# Altium schematic sheet RemoteTrigger.SchDoc
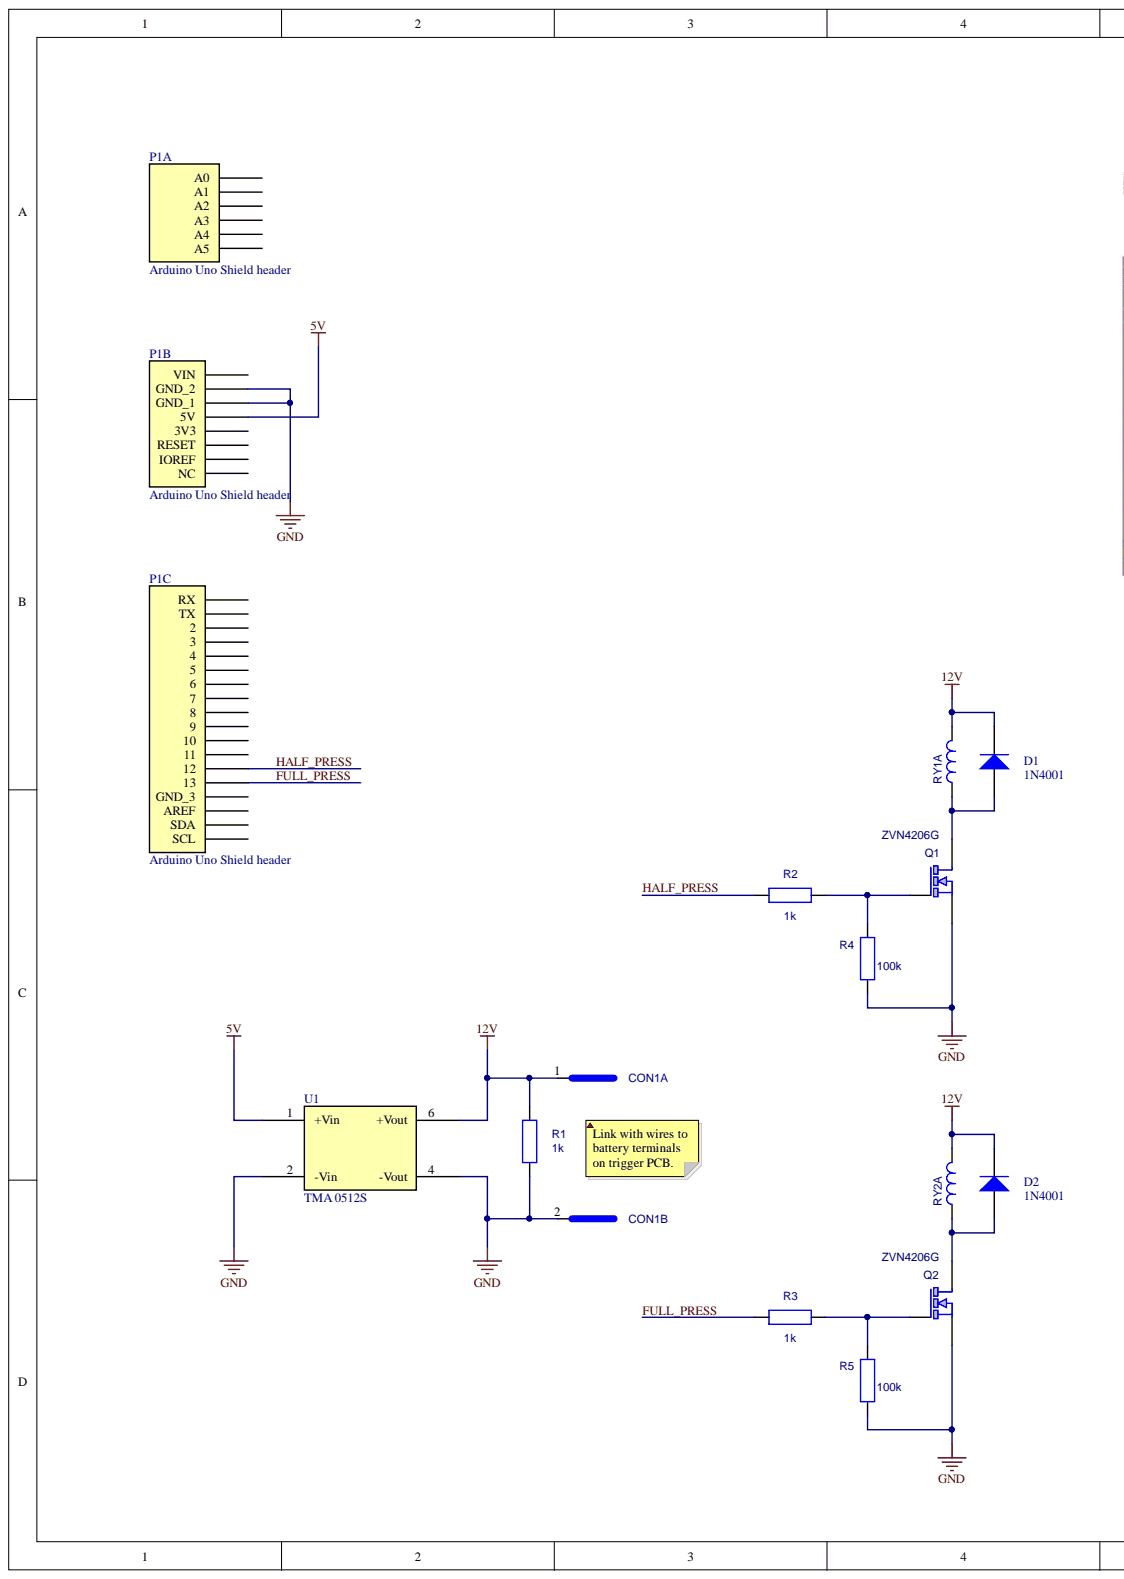
[diagram p0: RemoteTrigger.SchDoc, left]
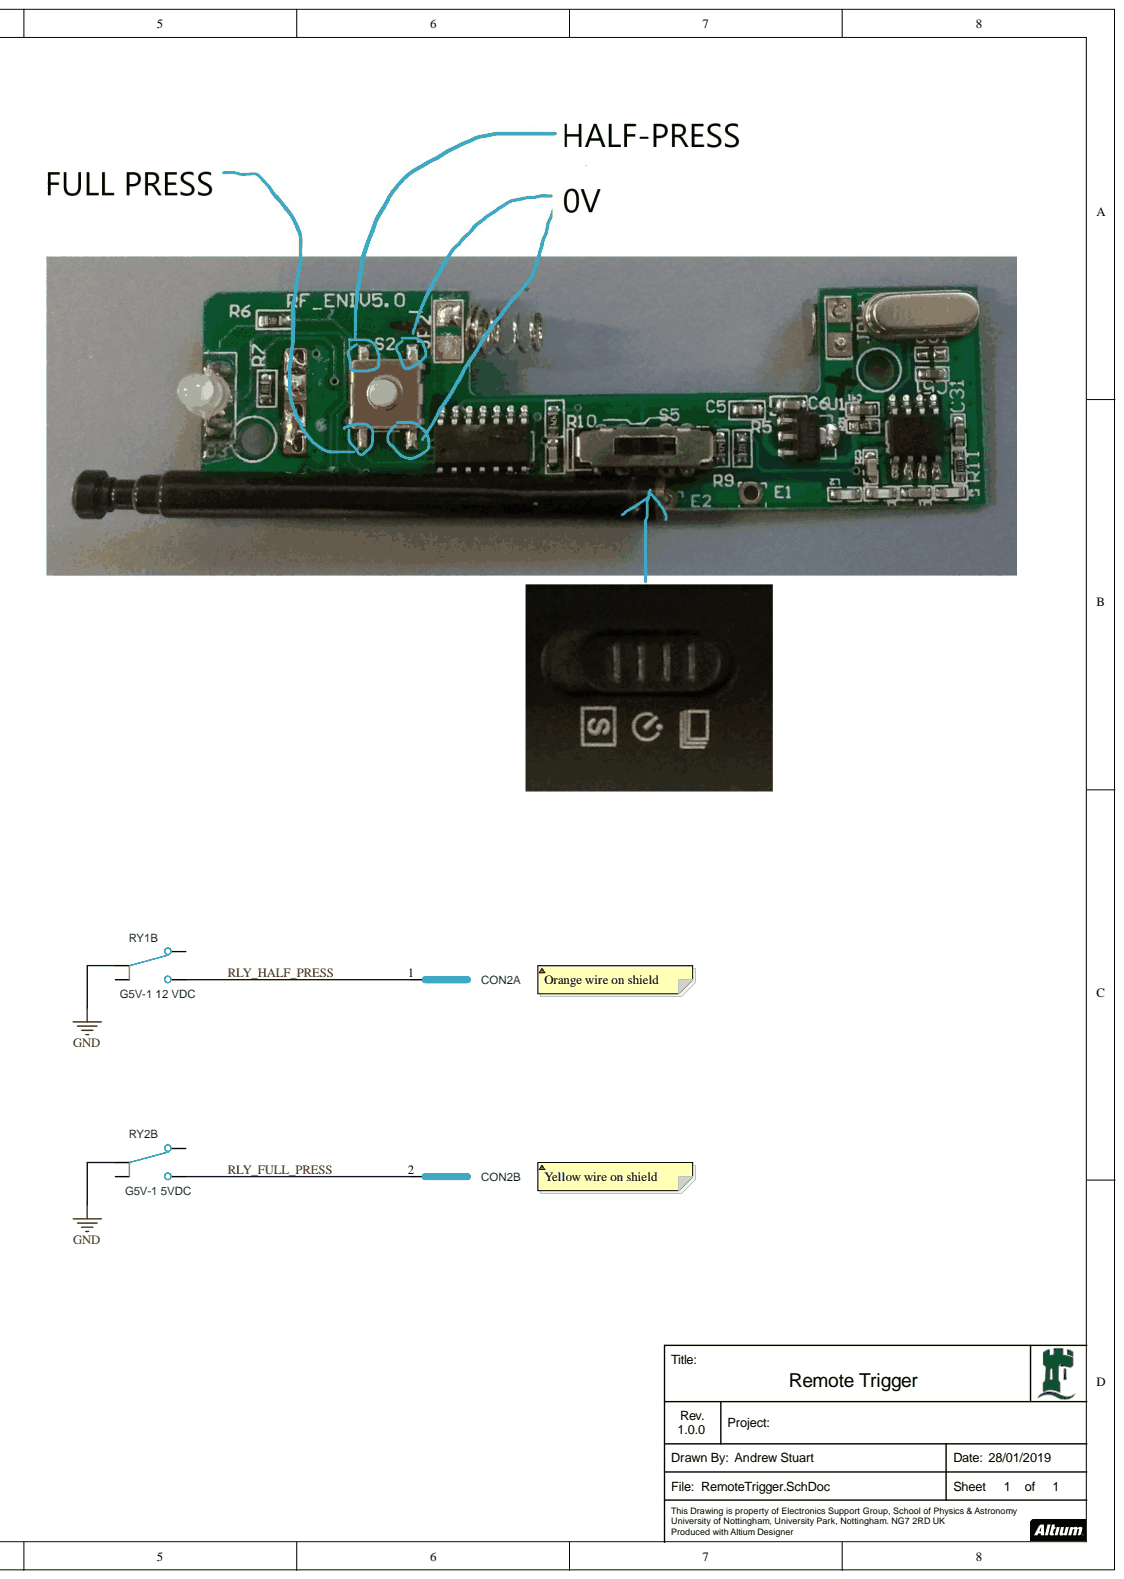
[diagram p1: RemoteTrigger.SchDoc, right]

## Parts
CON1  22-27-2021  [22-27-2021]  (value not drawn)  (parts A,B)
CON2  22-27-2021  [22-27-2021]  (value not drawn)  (parts A,B)
D1  1N4001  [DIO10.46-5.3x2.8]
D2  1N4001  [DIO10.46-5.3x2.8]
P1  Arduino Uno Shield header  [ARD_UNO_NOISCP]  (parts A,B,C)
Q1  ZVN4206G  [E-LINE]
Q2  ZVN4206G  [E-LINE]
R1  value 1k  [MRS25]  (hidden comment: MRS25)
R2  value 1k  [MRS25]  (hidden comment: MRS25)
R3  value 1k  [MRS25]  (hidden comment: MRS25)
R4  value 100k  [MRS25]  (hidden comment: MRS25)
R5  value 100k  [MRS25]  (hidden comment: MRS25)
RY1  G5V-1 12 VDC  [G5V-1]  (parts A,B)
RY2  G5V-1 5VDC  [G5V-1]  (parts A,B)
U1  TMA 0512S  [TMA XXXXS]

## Nets
5V: P1.5V U1.1(+Vin)
12V: CON1.1 D1.2 D2.2 R1.2 RY1.5 RY2.5 U1.6(+Vout)
GND: CON1.2 P1.GND_1 P1.GND_2 Q1.3 Q2.3 R1.1 R4.1 R5.1 RY1.3 RY2.3 U1.2(-Vin) U1.4(-Vout)
FULL_PRESS: P1.13 R3.1
HALF_PRESS: P1.12 R2.1
RLY_FULL_PRESS: CON2.2 RY2.6
RLY_HALF_PRESS: CON2.1 RY1.6
NetD1_1: D1.1 Q1.1 RY1.2
NetD2_1: D2.1 Q2.1 RY2.2
NetQ1_2: Q1.2 R2.2 R4.2
NetQ2_2: Q2.2 R3.2 R5.2

Not connected: P1 2 3 3V3 4 5 6 7 8 9 10 11 A0 A1 A2 A3 A4 A5 AREF GND_3 IOREF NC RESET RX SCL SDA TX VIN; RY1 1 4; RY2 1 4

## Library data of the parts
D1 D2 = Diode 1N4001: 1 Amp General Purpose Rectifier; Code_JEDEC: DO-204-AL; Component Kind: Standard; ComponentLink1Description: Manufacturer Link; ComponentLink1URL: http://www.fairchildsemi.com/; ComponentLink2Description: Datasheet; ComponentLink2URL: http://www.fairchildsemi.com/ds/1N%2F1N4001.pdf; DatasheetVersion: 1998; LatestRevisionDate: 17-Jul-2002; LatestRevisionNote: Re-released for DXP Platform.; Library Name: Miscellaneous Devices.IntLib; PackageReference: DO-41; PackageVersion: Aug-1999; Published: 8-Jun-2000; Signal Integrity: 1N4001; Simulation: 1N4001; Publisher: Altium Limited
P1 = ArduinoUno: Component Kind: Standard; Library Name: AS_connector.IntLib
Q1 Q2 = ZVN4206G: N-Channel MOSFET Enhancement mode DMOS ZVN4206A; Component Kind: Standard; Library Name: AS_transistors.IntLib; Simulation: ZVN4206G
R1-R5 = MRS25: Resistor through hole 0.6W; Component Kind: Standard; Library Name: AS_resistor.IntLib; Simulation: RESISTOR
RY2 = G5V-1 12 VDC: Component Kind: Standard; Description: G5V-1 12 VDC; Library Name: Omron Relay.IntLib
U1 = TMA_XXXXS: DC-DC converter, 1W, single output.; Component Kind: Standard; ComponentLink1Description: Datasheet; ComponentLink1URL: https://assets.tracopower.com/20181023104350/TMA/documents/tma-datasheet.pdf; Library Name: AS_psu.IntLib
CON1 CON2 = 22-27-2021: Component Kind: Standard; Description: 22-27-2021; Library Name: Molex Connector.IntLib
RY1 = G5V-1 12 VDC: Component Kind: Standard; Description: G5V-1 12 VDC; Library Name: Omron Relay.IntLib
